# Altium schematic sheet MPS_Battery Management.SchDoc("MPS_Battery Management")
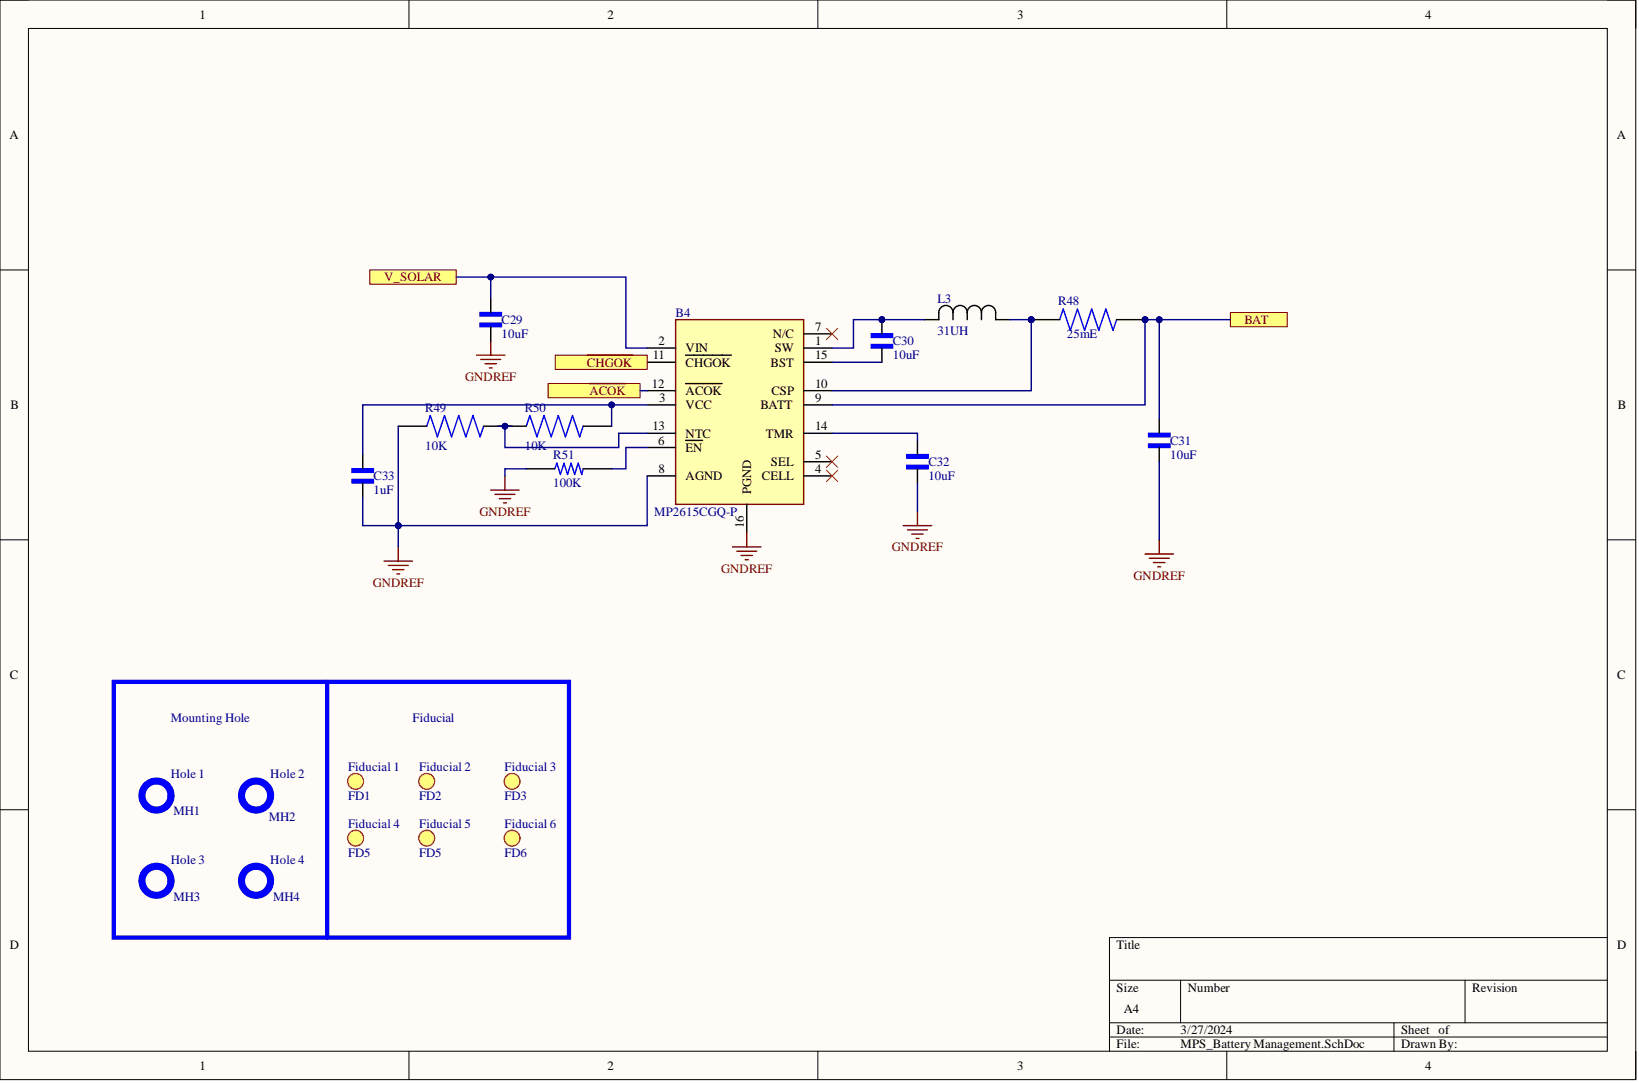
[diagram p0: MPS_Battery Management.SchDoc]

## Parts
B4  MP2615CGQ-P
C29  10uF
C30  10uF
C31  10uF
C32  10uF
C33  1uF
Fiducial_1  FD1
Fiducial_2  FD2
Fiducial_3  FD3
Fiducial_4  FD5
Fiducial_5  FD5
Fiducial_6  FD6
Hole_1  MH1
Hole_2  MH2
Hole_3  MH3
Hole_4  MH4
L3  31UH
R48  25mE
R49  10K
R50  10K
R51  100K

## Ports
BAT, V_SOLAR, ~{ACOK}, ~{CHGOK}

## Nets
GNDREF: B4.8(AGND) B4.16(PGND) C29.1 C31.1 C32.1 C33.2 R49.1 R51.1
~{ACOK}: B4.12(ACOK) ; port ~{ACOK}
BAT: B4.9(BATT) C31.2 R48.2 ; port BAT
~{CHGOK}: B4.11(CHGOK) ; port ~{CHGOK}
V_SOLAR: B4.2(VIN) C29.2 ; port V_SOLAR
NetB4_1: B4.1(SW) C30.2 L3.2
NetB4_3: B4.3(VCC) C33.1 R50.2
NetB4_6: B4.6(EN) R51.2
NetB4_10: B4.10(CSP) L3.1 R48.1
NetB4_13: B4.13(NTC) R49.2 R50.1
NetB4_14: B4.14(TMR) C32.2
NetB4_15: B4.15(BST) C30.1

Not connected: B4 4(CELL) 5(SEL) 7(N/C)

## Library data of the parts
B4 = MP2615CGQ-P: Battery Management 2.1A, 1- or 2-Cell L i-ion Battery Charg; Manufacturer_Name: Monolithic Power Systems (MPS); Geometry.Height: 1mm; Component Kind: Standard; Datasheet Link: https://www.monolithicpower.com/en/documentview/productdocument/index/version/2/document_type/datasheet/lang/en/sku/MP2615CGQ-Z/document_id/8689; Library Name: MP2615CGQ-P.SchLib; Manufacturer_Part_Number: MP2615CGQ-P; Mouser Part Number: 946-MP2615CGQ-P; Mouser Price/Stock: https://www.mouser.co.uk/ProductDetail/Monolithic-Power-Systems-MPS/MP2615CGQ-P?qs=yqaQSyyJnNhWta3fzFvGpA%3D%3D
C29-C32 = GRM188C81C106MA73J: Cap Ceramic 10uF 16V X6S 20% Pad SMD 0603 105Â°C T/R; MF: {'manufacturer': u'Murata'}; Availability: Unavailable; Component Kind: Standard; MP: GRM188C81C106MA73J; Package: None; Price: None
C33 = CC0603KRX5R8BB105: CERAMIC CAPACITOR, 1UF, 25V, X5R, 10%, 0603, FULL REEL; MF: {'manufacturer': u'Yageo'}; Availability: Unavailable; Component Kind: Standard; MP: CC0603KRX5R8BB105; Package: None; Price: None
Fiducial_1-Fiducial_6 = Component_1: Component Kind: Standard; Library Name: Fd.SchLib
Hole_1-Hole_4 = CMP-083-000001-1: Component Kind: Standard; Design Item ID: Component_1; Source: MH.SchLib; Library Name: Srushty Global Solutions Pvt Ltd
L3 = 7440660062: No Description Available; Mfr_Name: Wurth Electronics; Component Kind: Standard; Library Name: 7440660062.SchLib
R48 = RL1206FR-070R025L: Res Thick Film 1206 0.025 Ohm 1% 0.25W(1/4W) Â±1200ppm/Â°C Epoxy Pad SMD Automotive T/R; MF: {'manufacturer': u'Yageo'}; Availability: Unavailable; Component Kind: Standard; MP: RL1206FR-070R025L; Package: None; Price: None
R49 R50 = RC0603FR-0710KL: YAGEO RC0603FR-0710KL / RESISTOR 10K 1/10W 1% 0603 YAG <a href="https://pricing.snapeda.com/parts/RC0603FR-0710KL/Yageo/view-part?ref=eda">Check availability</a>; MF: {'manufacturer': u'Yageo'}; Availability: Unavailable; Component Kind: Standard; MP: RC0603FR-0710KL; Package: None; Price: None
R51 = RC0603FR-07100KL: RC Series 0603 0.1 W 100 kOhms 1% Â±100 ppm/Â°C SMT Thick Film Chip Resistor; MF: {'manufacturer': u'Yageo'}; Availability: Unavailable; Component Kind: Standard; MP: RC0603FR-07100KL; Package: None; Price: None
